# Altium schematic sheet IO.SchDoc
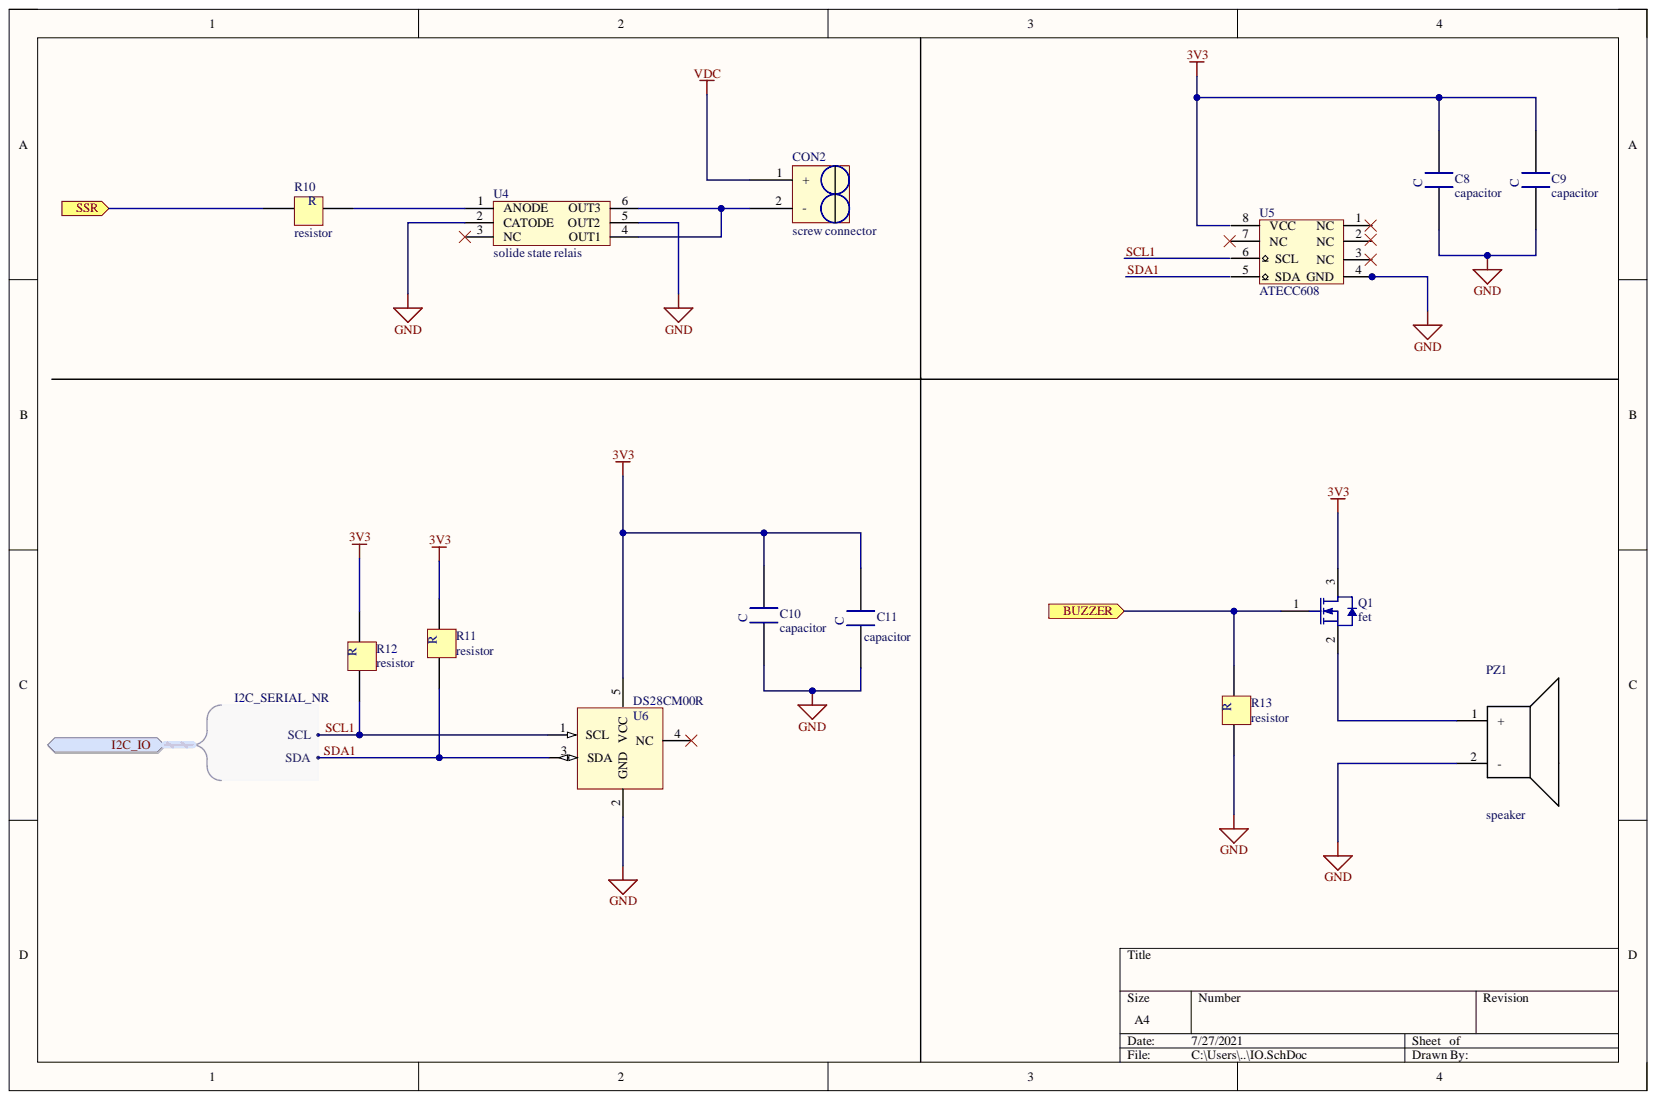
[diagram p0: IO.SchDoc]

## Parts
C8  capacitor  [CAPC1005X04L]
C9  capacitor  [CAPC1005X04L]
C10  capacitor  [CAPC1005X04L]
C11  capacitor  [CAPC1005X04L]
CON2  screw connector
PZ1  speaker
Q1  fet
R10  resistor  [CAPC1005X04L]
R11  resistor  [CAPC1005X04L]
R12  resistor  [CAPC1005X04L]
R13  resistor  [CAPC1005X04L]
U4  solide state relais
U5  ATECC608
U6  DS28CM00R

## Ports
BUZZER, I2C_IO, I2C_IO.SCL, I2C_IO.SDA, SSR

## Nets
3V3: C8.1 C9.1 C10.1 C11.1 Q1.3 R11.1 R12.1 U6.5(VCC)
GND: C8.2 C9.2 C10.2 C11.2 PZ1.2 R13.2 U4.2(CATODE) U4.5(OUT2) U5.4(GND) U6.2(GND)
VDC: CON2.1
BUZZER: Q1.1 R13.1 ; port BUZZER
SCL1: R12.2 U6.1(SCL) ; port I2C_IO
SDA1: R11.2 U6.3(SDA) ; port I2C_IO
NetCON2_2: CON2.2 U4.4(OUT1) U4.6(OUT3)
NetPZ1_1: PZ1.1 Q1.2
NetR10_1: R10.1
NetR10_2: R10.2
NetU4_1: U4.1(ANODE)
NetU4_3: U4.3(NC)
NetU5_1: U5.1(NC)
NetU5_2: U5.2(NC)
NetU5_3: U5.3(NC)
NetU5_5: U5.5(SDA)
NetU5_6: U5.6(SCL)
NetU5_7: U5.7(NC)
NetU5_8: U5.8(VCC)
NetU6_4: U6.4(NC)
N00001: ; port SSR

## Library data of the parts
U4 = G3VM-61HR2(TR05): Component Kind: Standard; Library Name: halfgelijders.SchLib
C8-C11 = capacitor: Component Kind: Standard; Library Name: pasives.SchLib
CON2 = screw connector: Component Kind: Standard; Description: screw connector; Library Name: conector's_and_TP.SchLib
PZ1 = speaker: Component Kind: Standard; Library Name: pasives.SchLib
Q1 = fet: Component Kind: Standard; Library Name: halfgelijders.SchLib
R10-R13 = resistor: Component Kind: Standard; Library Name: pasives.SchLib
U5 = ATECC608: Component Kind: Standard; Library Name: halfgelijders.SchLib
U6 = DS28CM00R: Component Kind: Standard; Library Name: halfgelijders.SchLib
